# Altium schematic sheet Teensy Connections for SSS2 Rev 6.SchDoc("Teensy Connections for SSS2 Rev 6")
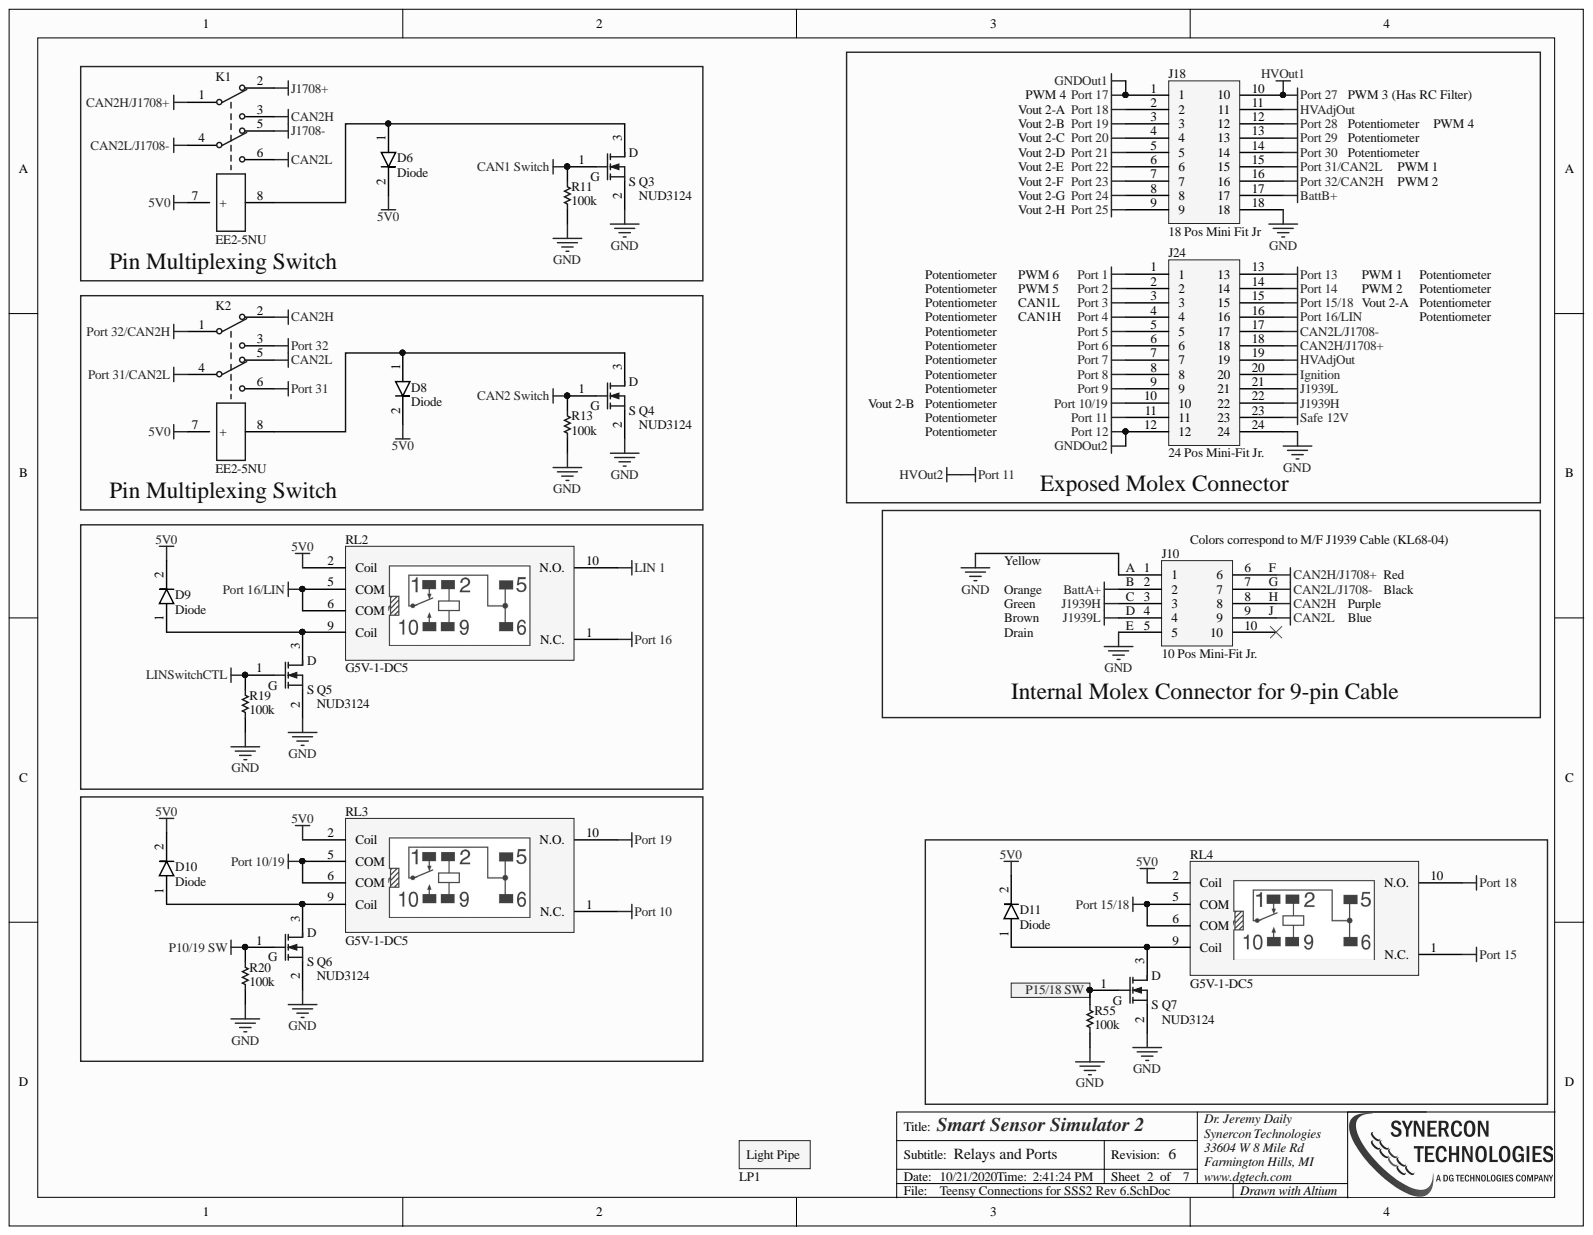
[diagram p0: Teensy Connections for SSS2 Rev 6.SchDoc]

## Parts
D6  Diode  [CST2C]
D8  Diode  [CST2C]
D9  Diode  [CST2C]
D10  Diode  [CST2C]
D11  Diode  [CST2C]
J10  10 Pos Mini-Fit Jr.  [10 pos Mini-Fit Jr. R/A Header]
J18  18 Pos Mini Fit Jr  [18 pos Mini-Fit Jr. R/A Header]
J24  24 Pos Mini-Fit Jr.  [24 pos Mini-Fit Jr. R/A Header]
K1  EE2-5NU  [RELAY - EE2]
K2  EE2-5NU  [RELAY - EE2]
LP1  Light Pipe  [Lightpipe - Dual]
Q3  NUD3124  [ONSC-SOT-23-3-318-08_V]
Q4  NUD3124  [ONSC-SOT-23-3-318-08_V]
Q5  NUD3124  [ONSC-SOT-23-3-318-08_V]
Q6  NUD3124  [ONSC-SOT-23-3-318-08_V]
Q7  NUD3124  [ONSC-SOT-23-3-318-08_V]
R11  100k  [0603]
R13  100k  [0603]
R19  100k  [0603]
R20  100k  [0603]
R55  100k  [0603]
RL2  G5V-1-DC5  [OMRON G5V Relay]
RL3  G5V-1-DC5  [OMRON G5V Relay]
RL4  G5V-1-DC5  [OMRON G5V Relay]

## Ports
P15/18 SW

## Nets
5V0: D6.2 D8.2 D9.2 D10.2 D11.2 K1.7(+) K2.7(+) RL2.2(Coil) RL3.2(Coil) RL4.2(Coil)
BattA+: J10.2
BattB+: J18.17
CAN1 Switch: Q3.1 R11.2
CAN2 Switch: Q4.1 R13.2
CAN2H: J10.8 K1.3 K2.2
CAN2H/J1708+: J10.6 J24.18 K1.1
CAN2L: J10.9 K1.6 K2.5
CAN2L/J1708-: J10.7 J24.17 K1.4
GND: J10.1 J10.5 J18.18 J24.24 Q3.2 Q4.2 Q5.2 Q6.2 Q7.2 R11.1 R13.1 R19.1 R20.1 R55.1
GNDOut1: J18.1
GNDOut2: J24.12
HVAdjOut: J18.11 J24.19
HVOut1: J18.10
HVOut2: J24.11
Ignition: J24.20
J1708+: K1.2
J1708-: K1.5
J1939H: J10.3 J24.22
J1939L: J10.4 J24.21
LIN 1: RL2.10(N.O.)
LINSwitchCTL: Q5.1 R19.2
P10/19 SW: Q6.1 R20.2
Port 1: J24.1
Port 2: J24.2
Port 3: J24.3
Port 4: J24.4
Port 5: J24.5
Port 6: J24.6
Port 7: J24.7
Port 8: J24.8
Port 9: J24.9
Port 10: RL3.1(N.C.)
Port 10/19: J24.10 RL3.5(COM) RL3.6(COM)
Port 13: J24.13
Port 14: J24.14
Port 15: RL4.1(N.C.)
Port 15/18: J24.15 RL4.5(COM) RL4.6(COM)
Port 16: RL2.1(N.C.)
Port 16/LIN: J24.16 RL2.5(COM) RL2.6(COM)
Port 18: J18.2 RL4.10(N.O.)
Port 19: J18.3 RL3.10(N.O.)
Port 20: J18.4
Port 21: J18.5
Port 22: J18.6
Port 23: J18.7
Port 24: J18.8
Port 25: J18.9
Port 28: J18.12
Port 29: J18.13
Port 30: J18.14
Port 31: K2.6
Port 31/CAN2L: J18.15 K2.4
Port 32: K2.3
Port 32/CAN2H: J18.16 K2.1
Safe 12V: J24.23
P15/18 SW: Q7.1 R55.2 ; port P15/18 SW
NetD6_1: D6.1 K1.8 Q3.3
NetD8_1: D8.1 K2.8 Q4.3
NetD9_1: D9.1 Q5.3 RL2.9(Coil)
NetD10_1: D10.1 Q6.3 RL3.9(Coil)
NetD11_1: D11.1 Q7.3 RL4.9(Coil)

Not connected: J10 10

## Library data of the parts
D6 D8-D11 = Diode: Diode Schottky 40V 2A Surface Mount DO-214AC (SMA); PartNumber: CCS15S30L3FCT-ND; Manufacturer: Toshiba Semiconductor and Storage; Supplier Part Number 1: CCS15S30L3FCT-ND; Supplier 1: Digi-Key; Simulation: DIODE; Signal Integrity: Diode; Library Name: SSS Integrated Library.IntLib; Component Kind: Standard
J10 = 10 Pos Header: 10 Positions Header - Shrouded Connector 0.165in (4.20mm) Through Hole - Right Angle Gold; Manufacturer: Molex; Supplier Part Number 1: WM21367-ND; Supplier 1: Digi-Key; PartNumber: 39-30-1102; Library Name: Molex Mini-Fit Jr.IntLib; Component Kind: Standard
J18 = 18 Pos Header: 18 Positions Header - Shrouded Connector 0.165in (4.20mm) Through Hole - Right Angle Gold; Manufacturer: Molex; Supplier Part Number 1: WM21371-ND; Supplier 1: Digi-Key; PartNumber: 39-30-1182; Library Name: Molex Mini-Fit Jr.IntLib; Component Kind: Standard
J24 = 24 Pos Header: 24 Positions Header  Shrouded Connector 0.165in (4.20mm) Through Hole  Right Angle Gold; Manufacturer: Molex; Supplier Part Number 1: WM21374-ND; Supplier 1: Digi-Key; PartNumber: 39-30-1242; Library Name: Molex Mini-Fit Jr.IntLib; Component Kind: Standard
K1 K2 = DPDT Relay: General Purpose Relay DPDT (2 Form C) Surface Mount; PartNumber: EE2-5NU-L; Manufacturer: KEMET; Supplier Part Number 1: 399-11017-1-ND; Supplier 1: Digi-Key; Library Name: Custom Parts Schematics.SchLib; Component Kind: Standard
LP1 = Light Pipe: Light Pipe 2 High Clear Rigid Round with Domed Top, 3mm Board Mount, Press Fit, Right Angle; PartNumber: LP-230; Manufacturer: Bivar Inc.; Supplier Part Number 1: 492-1859-ND; Supplier 1: Digi-Key; Library Name: SSS Schematic Library.SchLib; Component Kind: Standard
Q3 Q4 = IRLML6344TRPbF: IC INDCT LOAD DRVR AUTO SOT23; PartNumber: NUD3124LT1G; Manufacturer: ON Semiconductor; Alternative: None; Supplier Part Number 1: NUD3124LT1GOSCT-ND; Supplier 1: Digi-Key; Library Name: SSS Integrated Library.IntLib; Component Kind: Standard
Q5-Q7 = IRLML6344TRPbF: IC INDCT LOAD DRVR AUTO SOT23; PartNumber: NUD3124LT1G; Manufacturer: ON Semiconductor; Supplier Part Number 1: NUD3124LT1GOSCT-ND; Supplier 1: Digi-Key; Library Name: SSS Schematic Library.SchLib; Component Kind: Standard
R11 R13 R19 R20 R55 = Chip Resistor: 100 kOhms ±1% 0.1W, 1/10W Chip Resistor 0603 (1608 Metric) Automotive AEC-Q200 Thick Film; PartNumber: ERJ-3EKF1003V; Manufacturer: Panasonic Electronic Components; Alternative: Acceptable; Supplier Part Number 1: P100KHCT-ND; Supplier 1: Digi-Key; Library Name: SSS Integrated Library.IntLib; Component Kind: Standard
RL2-RL4 = Relay-G5V: OMRON RELAY GENERAL PURPOSE SPDT 1A 5V; Manufacturer: Omron Electronics Inc-EMC Div; Supplier Part Number 1: Z773-ND; Supplier 1: Digi-Key; PartNumber: G5V-1-DC5; Library Name: SSS Schematic Library.SchLib; Component Kind: Standard
